# Altium schematic sheet OVERVIEW.SchDoc(OVERVIEW)
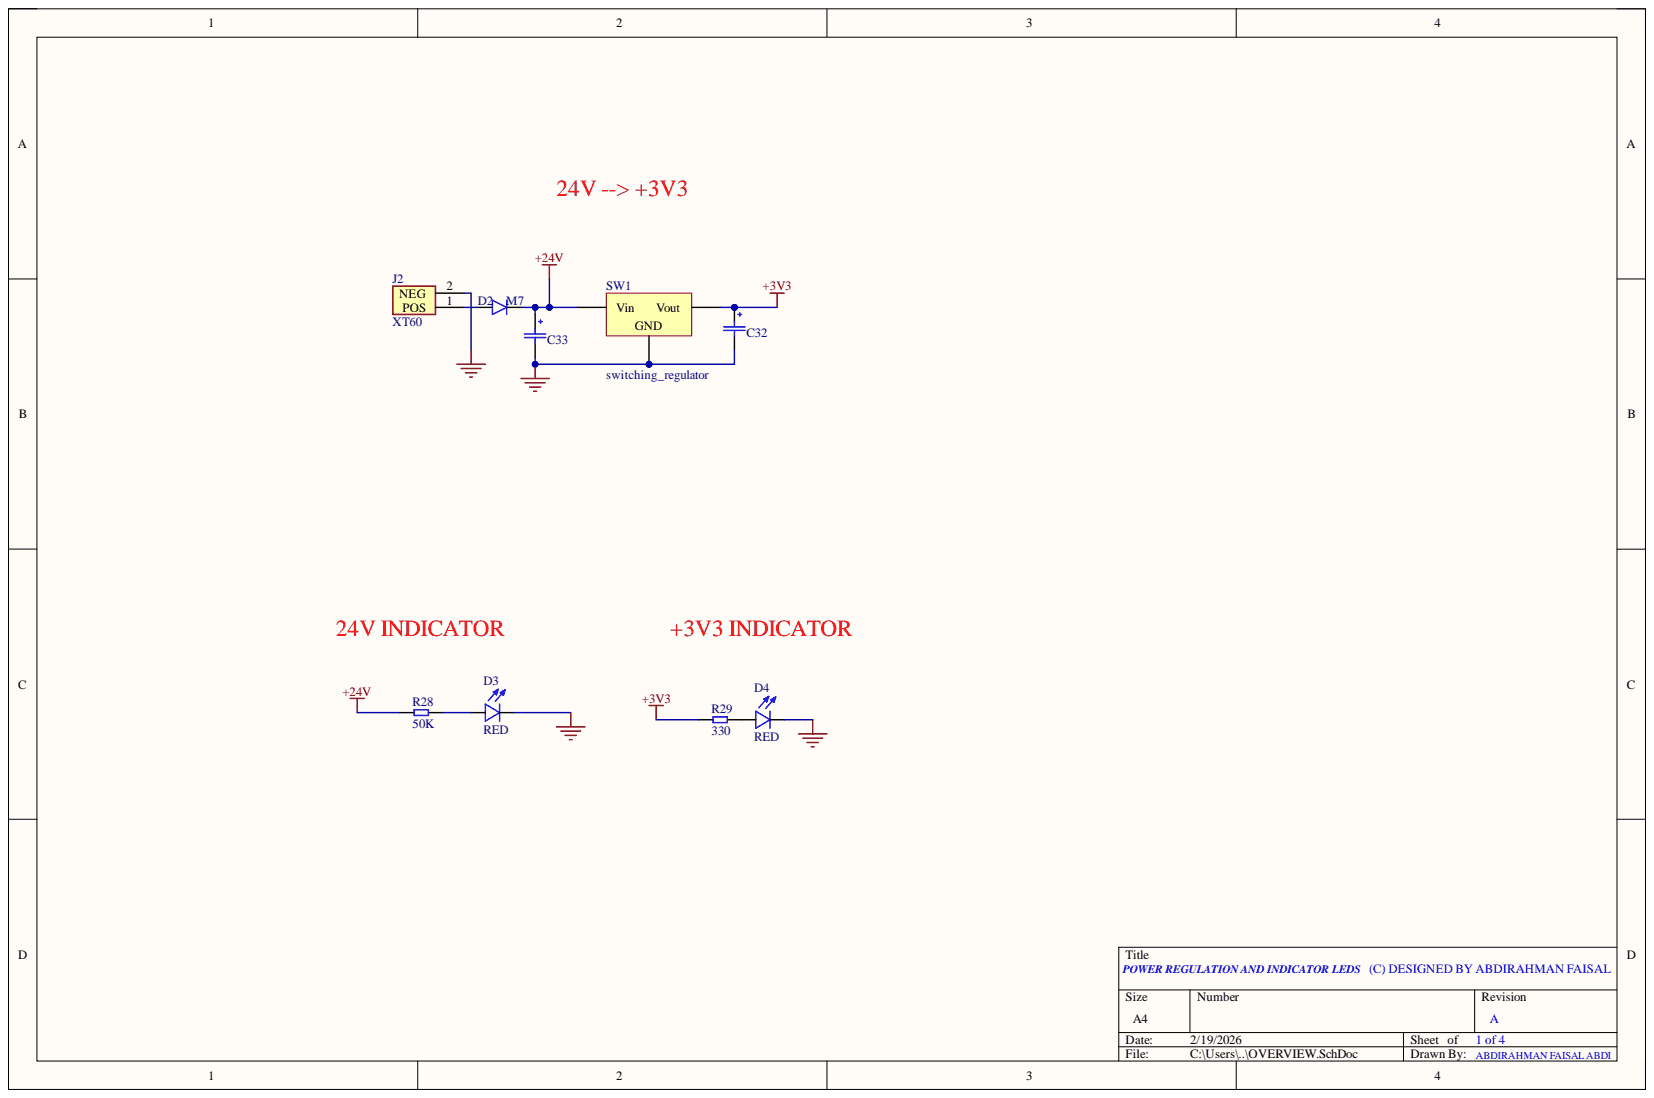
[diagram p0: OVERVIEW.SchDoc]

## Parts
C32  UCM1H331MNL1GS  [CAP_UCD_10X10_NCH]  (value not drawn)
C33  UCM1H331MNL1GS  [CAP_UCD_10X10_NCH]  (value not drawn)
D2  M7  [DIOM505270X240]
D3  RED  [LED_VLMS1300-GS08]
D4  RED  [LED_VLMS1300-GS08]
J2  XT60  [AMASS_XT60PW-M]
R28  50K  [R0603]
R29  330  [R0603]
SW1  switching_regulator  [SWITCHING REGULATOR]

## Nets
+3V3: C32.1 R29.1 SW1.3
+24V: C33.1 D2.C R28.1 SW1.1
GND: C32.2 C33.2 D3.C D4.C J2.2 SW1.2
NetD2_A: D2.A J2.1
NetD3_A: D3.A R28.2
NetD4_A: D4.A R29.2

## Library data of the parts
C32 C33 = UCM1H331MNL1GS: CAP ALUM 330UF 20% 50V SMD; Manufacturer: Nichicon; Category: Aluminum Electrolytic Capacitors; Component Kind: Standard; ComponentLink1Description: http://uk.rs-online.com/webdocs/13bc/0900766b813bca52.pdf?src-supplier=Rs; ComponentLink1URL: http://uk.rs-online.com/webdocs/13bc/0900766b813bca52.pdf?src-supplier=Rs; ComponentLink2Description: http://www.mouser.com/ds/2/293/e-ucm-883824.pdf?src-supplier=Mouser; ComponentLink2URL: http://www.mouser.com/ds/2/293/e-ucm-883824.pdf?src-supplier=Mouser; ComponentLink3Description: http://nichicon-us.com/english/products/pdfs/e-ucm.pdf?src-supplier=Digikey; ComponentLink3URL: http://nichicon-us.com/english/products/pdfs/e-ucm.pdf?src-supplier=Digikey; ComponentLink4Description: https://datasheet.octopart.com/UCM1H331MNL1GS-Nichicon-datasheet-151191225.pdf?src-supplier=Future+Electronics; ComponentLink4URL: https://datasheet.octopart.com/UCM1H331MNL1GS-Nichicon-datasheet-151191225.pdf?src-supplier=Future+Electronics; ComponentLink5Description: https://datasheet.octopart.com/UCM1H331MNL1GS-Nichicon-datasheet-66487647.pdf?src-supplier=TME; ComponentLink5URL: https://datasheet.octopart.com/UCM1H331MNL1GS-Nichicon-datasheet-66487647.pdf?src-supplier=TME; LCSC part number: C729686; Library Name: IO.SchLib; Manufacturer Part Number: UCM1H331MNL1GS; Pricing 1: 1=1.18, 10=0.757, 50=0.5786, 100=0.5221 (USD); RoHS: Yes; Stock 1: 13467; Supplier 1: Digikey
D2 = M7: DIODE STANDARD 1000V 1A DO214AC; Manufacturer: Diotec; Category: Rectifier Diodes; Component Kind: Standard; ComponentLink1Description: https://datasheet.octopart.com/M7-Diotec-datasheet-113243034.pdf?src-supplier=TME; ComponentLink1URL: https://datasheet.octopart.com/M7-Diotec-datasheet-113243034.pdf?src-supplier=TME; LCSC part number: C85148; Library Name: IO.SchLib; Manufacturer Part Number: M7; Pricing 1: 1=0.1, 10=0.055, 100=0.0402, 500=0.03234, 1000=0.02906, 2000=0.0257 (USD); RoHS: Yes; Stock 1: 88613; Supplier 1: Digikey
D3 D4 = VLMS1300-GS08: LED RED 0603 SMD; Manufacturer: Vishay Semiconductors; Dominant Wavelength: 631nm; Forward Current: 20mA; Forward Voltage: 2V; Lens Style: Rectangular,Clear; Luminous Intensity: 54mcd; Number of LEDs: 1; Power Rating: 75mW; Viewing Angle: 130°; Case Code (Imperial): 0603; Case Code (Metric): 1608; Case/Package: 0603; Category: LEDs; Color: Red; Component Kind: Standard; ComponentLink1Description: https://datasheet.ciiva.com/26322/vlmo1300-26322153.pdf?src-supplier=Element14; ComponentLink1URL: https://datasheet.ciiva.com/26322/vlmo1300-26322153.pdf?src-supplier=Element14; ComponentLink2Description: https://datasheet.ciiva.com/8028/vlmo1300-8028047.pdf?src-supplier=Element14; ComponentLink2URL: https://datasheet.ciiva.com/8028/vlmo1300-8028047.pdf?src-supplier=Element14; ComponentLink3Description: https://datasheet.ciiva.com/9333/vlmo1300-9333743.pdf?src-supplier=Avnet; ComponentLink3URL: https://datasheet.ciiva.com/9333/vlmo1300-9333743.pdf?src-supplier=Avnet; ComponentLink4Description: https://datasheet.ciiva.com/16510/vlmo1300-16510985.pdf?src-supplier=Future+Electronics; ComponentLink4URL: https://datasheet.ciiva.com/16510/vlmo1300-16510985.pdf?src-supplier=Future+Electronics; Height: 0.8mm; Illumination Color: Red; LCSC part number: C83242; Lead Free: Lead Free; Length: 1.6mm; Library Name: IO.SchLib; Manufacturer Part Number: VLMS1300-GS08; Max Operating Temperature: 85°C; Min Operating Temperature: -35°C; Mount: Surface Mount; Package Quantity: 1; Packaging: Tape and Reel; Pricing 1: 1=0.21, 10=0.143, 100=0.0998, 500=0.07982, 1000=0.07322 (USD); Radiation Hardening: No; Reach SVHC Compliant: Unknown; RoHS: Yes; RoHS Compliant: Yes; Series: CHipLED; Stock 1: 42495; Supplier 1: Digikey; Test Current: 20mA; Wavelength: 631nm; Width: 0.8mm
J2 = XT60PW-M: XT60PW Male, yellow; Manufacturer: Unclassified; Category: LDO; Component Kind: Standard; ComponentLink1Description: New link; LCSC part number: C98732; Library Name: IO.SchLib; Manufacturer Part Number: XT60PW-M; RoHS: No; Supplier 1: Rs
R28 = RT0603BRD0750KL: RES 50K OHM 0.1% 1/10W 0603; Manufacturer: Yageo; Max Power Dissipation: 100mW; Resistance: 50kR; Temperature Coefficient: 25ppm/°C; Voltage Rating: 75V; Case/Package: 0603; Category: Chip SMD Resistors; Component Kind: Standard; ComponentLink1Description: https://www.yageo.com/upload/media/product/productsearch/datasheet/rchip/PYu-RT_1-to-0.01_RoHS_L_12.pdf?src-supplier=Digikey; ComponentLink1URL: https://www.yageo.com/upload/media/product/productsearch/datasheet/rchip/PYu-RT_1-to-0.01_RoHS_L_12.pdf?src-supplier=Digikey; ComponentLink2Description: https://datasheet.octopart.com/RT0603BRD0750KL-Yageo-datasheet-164439670.pdf?src-supplier=Newark; ComponentLink2URL: https://datasheet.octopart.com/RT0603BRD0750KL-Yageo-datasheet-164439670.pdf?src-supplier=Newark; ComponentLink3Description: https://datasheet.octopart.com/RT0603BRD0750KL-Yageo-datasheet-176402239.pdf?src-supplier=Element14; ComponentLink3URL: https://datasheet.octopart.com/RT0603BRD0750KL-Yageo-datasheet-176402239.pdf?src-supplier=Element14; ComponentLink4Description: https://datasheet.octopart.com/RT0603BRD0750KL-Yageo-datasheet-169971040.pdf?src-supplier=Future+Electronics; ComponentLink4URL: https://datasheet.octopart.com/RT0603BRD0750KL-Yageo-datasheet-169971040.pdf?src-supplier=Future+Electronics; ComponentLink5Description: https://datasheet.octopart.com/RT0603BRD0750KL-Yageo-datasheet-176402239.pdf?src-supplier=Farnell; ComponentLink5URL: https://datasheet.octopart.com/RT0603BRD0750KL-Yageo-datasheet-176402239.pdf?src-supplier=Farnell; Height: 550um; LCSC part number: C861451; Library Name: IO.SchLib; Manufacturer Part Number: RT0603BRD0750KL; Max Operating Temperature: 155°C; Min Operating Temperature: -55°C; Number of Terminations: 2; Packaging: Tape and Reel; Power Rating: 100mW; Pricing 1: 1=0.14, 10=0.108, 25=0.0976, 50=0.0868, 100=0.0775, 250=0.06884, 500=0.06018, 1000=0.05304 (USD); RoHS: No; Series: RT; Stock 1: 3136; Supplier 1: Digikey; Tolerance: 0.1%
R29 = RC0603FR-07330RL: RES 330 OHM 1% 1/10W 0603; Manufacturer: Yageo; Capacitance: 47uF; ESR (Equivalent Series Resistance): 800mR; Max Power Dissipation: 100mW; Resistance: 330R; Temperature Coefficient: 100ppm/°C; Voltage Rating: 50V; Case Code (Imperial): 0603; Case Code (Metric): 1608; Case/Package: 0603; Category: Chip SMD Resistors; Component Kind: Standard; Depth: 0.8mm; Features: Moisture Resistant; Height: 0.45mm; LCSC part number: C105881; Lead Free: 5697LeadFree; Length: 1.6mm; Library Name: IO.SchLib; Manufacturer Part Number: RC0603FR-07330RL; Max Operating Temperature: 155°C; Min Operating Temperature: -55°C; Number of Pins: 2; Number of Terminations: 2; Package Quantity: 1; Packaging: Tape and Reel; Power Rating: 100mW; Pricing 1: 1=0.1, 10=0.009, 100=0.0082, 1000=0.00612, 2500=0.00461 (USD); Reach SVHC Compliant: No; Resistance Tolerance: 1%; Resistor Type: Thick Film; RoHS: Yes; RoHS Compliant: Yes; Series: RC; Size Code: 0603; Stock 1: 425796; Supplier 1: Digikey; Technology: THICK FILM; Termination: SMD/SMT; Tolerance: 1%; Voltage Rating (AC): 50V; Voltage Rating (DC): 50V; Width: 0.8mm
SW1 = switching_regulator: Component Kind: Standard; Library Name: IO.SchLib
